# Altium schematic sheet 02_Endstufe.SchDoc(02_Endstufe)
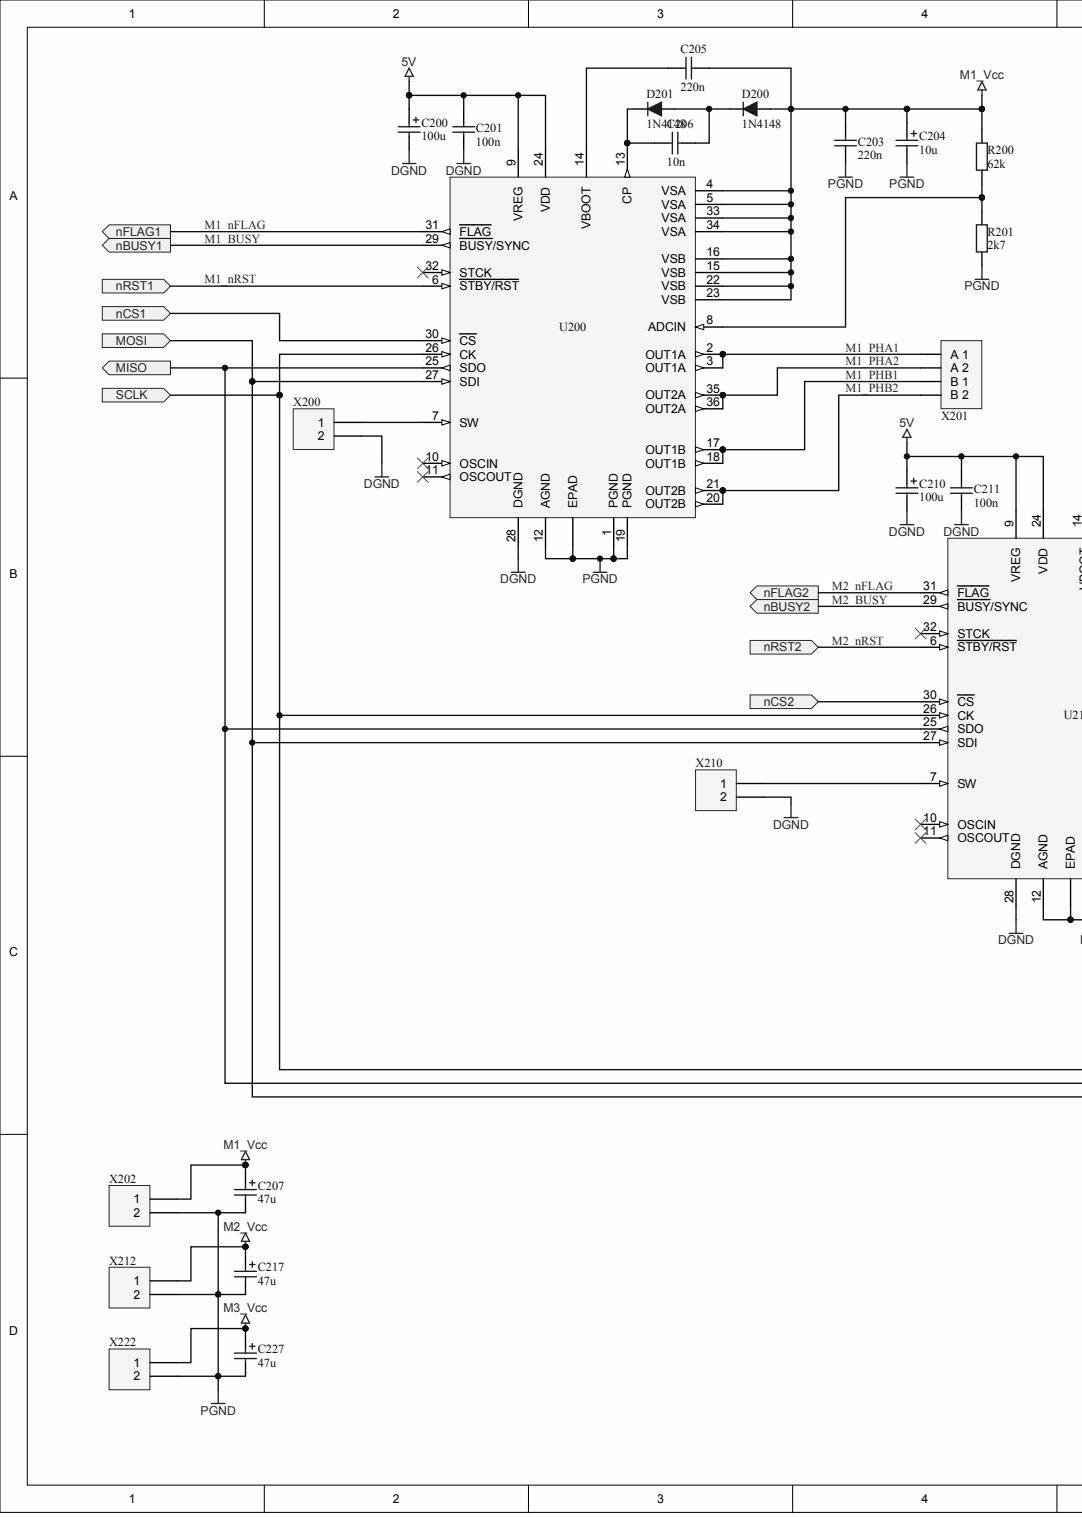
[diagram p0: 02_Endstufe.SchDoc, left]
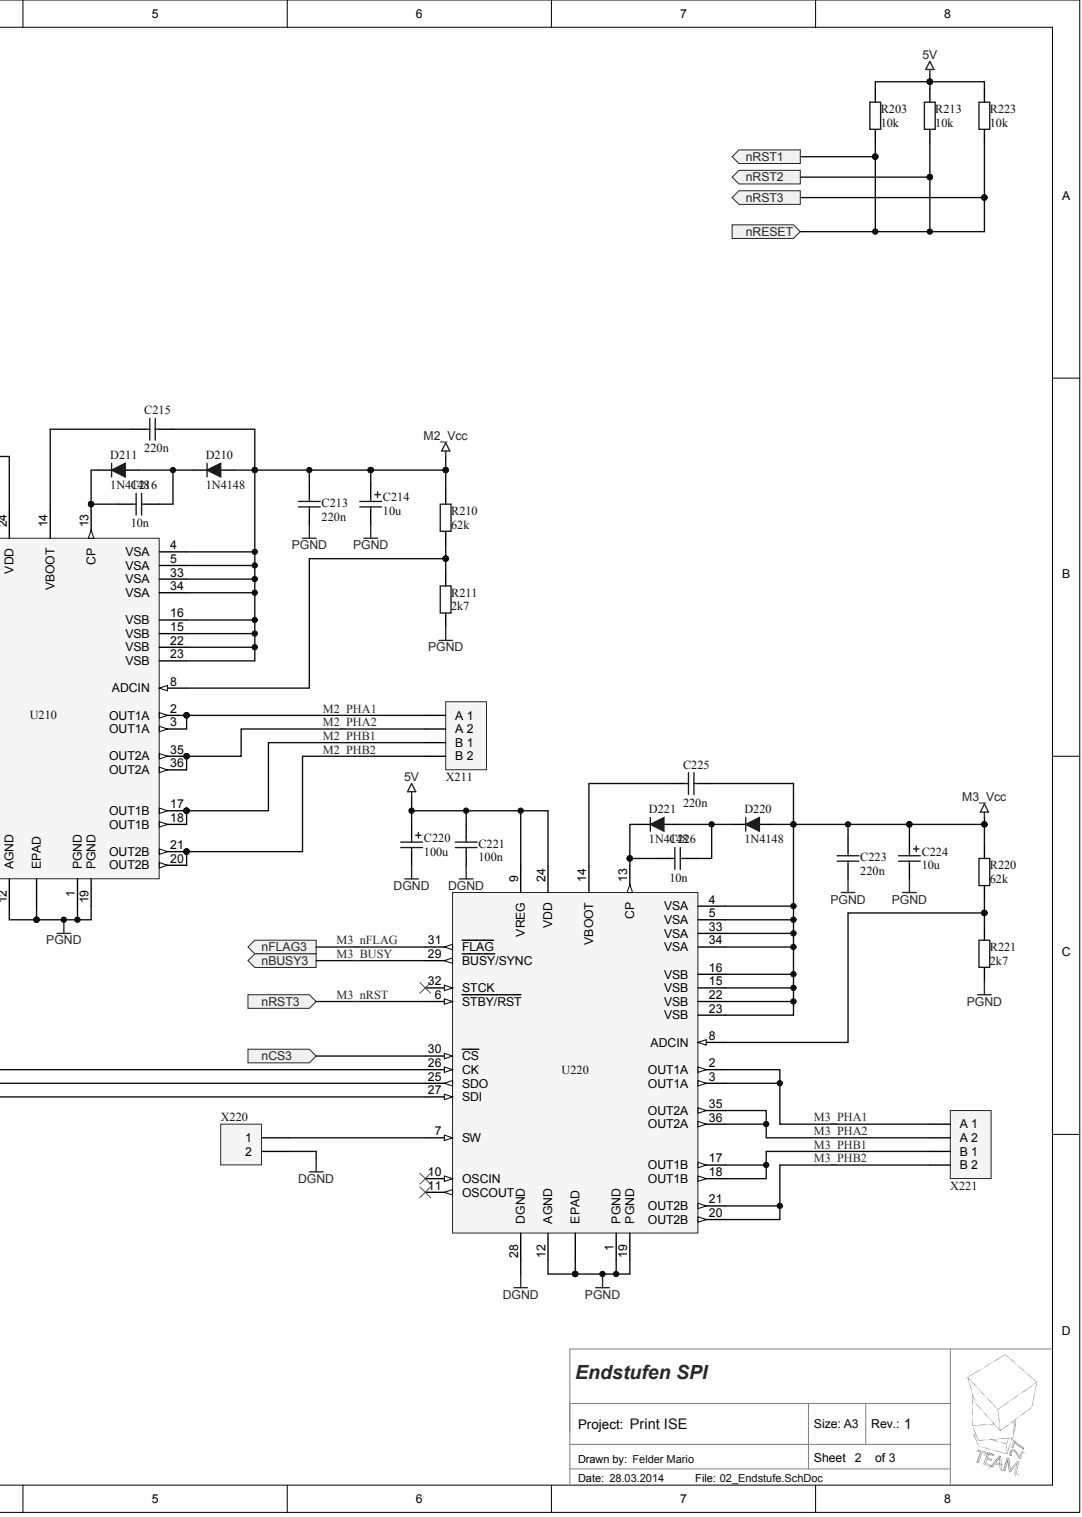
[diagram p1: 02_Endstufe.SchDoc, right]

## Parts
C200  100u
C201  100n
C203  220n
C204  10u
C205  220n
C206  10n
C207  47u
C210  100u
C211  100n
C213  220n
C214  10u
C215  220n
C216  10n
C217  47u
C220  100u
C221  100n
C223  220n
C224  10u
C225  220n
C226  10n
C227  47u
D200  1N4148
D201  1N4148
D210  1N4148
D211  1N4148
D220  1N4148
D221  1N4148
R200  62k
R201  2k7
R203  10k
R210  62k
R211  2k7
R213  10k
R220  62k
R221  2k7
R223  10k
U200  L6470 PD  (value not drawn)
U210  L6470 PD  (value not drawn)
U220  L6470 PD  (value not drawn)
X200  Header 2  (value not drawn)
X201  Motor_Connector  (value not drawn)
X202  Header 2  (value not drawn)
X210  Header 2  (value not drawn)
X211  Motor_Connector  (value not drawn)
X212  Header 2  (value not drawn)
X220  Header 2  (value not drawn)
X221  Motor_Connector  (value not drawn)
X222  Header 2  (value not drawn)

## Ports
MISO, MOSI, NBUSY1, NBUSY2, NBUSY3, NCS1, NCS2, NCS3, NFLAG1, NFLAG2, NFLAG3, NRESET, NRST1, NRST2, NRST3, SCLK

## Nets
5V: C200.1 C201.1 C210.1 C211.1 C220.1 C221.1 R203.2 R213.2 R223.2 U200.9(VREG) U200.24(VDD) U210.9(VREG) U210.24(VDD) U220.9(VREG) U220.24(VDD)
DGND: C200.2 C201.2 C210.2 C211.2 C220.2 C221.2 U200.28(DGND) U210.28(DGND) U220.28(DGND) X200.2 X210.2 X220.2
M1_VCC: C203.1 C204.1 C205.2 C207.1 D200.A R200.1 U200.4(VSA) U200.5(VSA) U200.15(VSB) U200.16(VSB) U200.22(VSB) U200.23(VSB) U200.33(VSA) U200.34(VSA) X202.1
M2_VCC: C213.1 C214.1 C215.2 C217.1 D210.A R210.1 U210.4(VSA) U210.5(VSA) U210.15(VSB) U210.16(VSB) U210.22(VSB) U210.23(VSB) U210.33(VSA) U210.34(VSA) X212.1
M3_VCC: C223.1 C224.1 C225.2 C227.1 D220.A R220.1 U220.4(VSA) U220.5(VSA) U220.15(VSB) U220.16(VSB) U220.22(VSB) U220.23(VSB) U220.33(VSA) U220.34(VSA) X222.1
PGND: C203.2 C204.2 C207.2 C213.2 C214.2 C217.2 C223.2 C224.2 C227.2 R201.2 R211.2 R221.2 U200.0(EPAD) U200.1(PGND) U200.12(AGND) U200.19(PGND) U210.0(EPAD) U210.1(PGND) U210.12(AGND) U210.19(PGND) U220.0(EPAD) U220.1(PGND) U220.12(AGND) U220.19(PGND) X202.2 X212.2 X222.2
M1_BUSY: U200.29(BUSY/SYNC) ; port NBUSY1
M1_NFLAG: U200.31(FLAG) ; port NFLAG1
M1_NRST: R203.1 R213.1 R223.1 U200.6(STBY/RST) U210.6(STBY/RST) U220.6(STBY/RST) ; port NRESET, port NRST1, port NRST2, port NRST3
M1_PHA1: U200.2(OUT1A) U200.3(OUT1A) X201.1(A_1)
M1_PHA2: U200.35(OUT2A) U200.36(OUT2A) X201.2(A_2)
M1_PHB1: U200.17(OUT1B) U200.18(OUT1B) X201.3(B_1)
M1_PHB2: U200.20(OUT2B) U200.21(OUT2B) X201.4(B_2)
M2_BUSY: U210.29(BUSY/SYNC) ; port NBUSY2
M2_NFLAG: U210.31(FLAG) ; port NFLAG2
M2_PHA1: U210.2(OUT1A) U210.3(OUT1A) X211.1(A_1)
M2_PHA2: U210.35(OUT2A) U210.36(OUT2A) X211.2(A_2)
M2_PHB1: U210.17(OUT1B) U210.18(OUT1B) X211.3(B_1)
M2_PHB2: U210.20(OUT2B) U210.21(OUT2B) X211.4(B_2)
M3_BUSY: U220.29(BUSY/SYNC) ; port NBUSY3
M3_NFLAG: U220.31(FLAG) ; port NFLAG3
M3_PHA1: U220.2(OUT1A) U220.3(OUT1A) X221.1(A_1)
M3_PHA2: U220.35(OUT2A) U220.36(OUT2A) X221.2(A_2)
M3_PHB1: U220.17(OUT1B) U220.18(OUT1B) X221.3(B_1)
M3_PHB2: U220.20(OUT2B) U220.21(OUT2B) X221.4(B_2)
MISO: U200.25(SDO) U210.25(SDO) U220.25(SDO) ; port MISO
MOSI: U200.27(SDI) U210.27(SDI) U220.27(SDI) ; port MOSI
SCLK: U200.26(CK) U210.26(CK) U220.26(CK) ; port SCLK
NetC205_1: C205.1 U200.14(VBOOT)
NetC206_1: C206.1 D201.K U200.13(CP)
NetC206_2: C206.2 D200.K D201.A
NetC215_1: C215.1 U210.14(VBOOT)
NetC216_1: C216.1 D211.K U210.13(CP)
NetC216_2: C216.2 D210.K D211.A
NetC225_1: C225.1 U220.14(VBOOT)
NetC226_1: C226.1 D221.K U220.13(CP)
NetC226_2: C226.2 D220.K D221.A
NetR200_2: R200.2 R201.1 U200.8(ADCIN)
NetR210_2: R210.2 R211.1 U210.8(ADCIN)
NetR220_2: R220.2 R221.1 U220.8(ADCIN)
NetU200_7: U200.7(SW) X200.1
NetU200_30: U200.30(CS) ; port NCS1
NetU210_7: U210.7(SW) X210.1
NetU210_30: U210.30(CS) ; port NCS2
NetU220_7: U220.7(SW) X220.1
NetU220_30: U220.30(CS) ; port NCS3

Not connected: U200 10(OSCIN) 11(OSCOUT) 32(STCK); U210 10(OSCIN) 11(OSCOUT) 32(STCK); U220 10(OSCIN) 11(OSCOUT) 32(STCK)

## Library data of the parts
C200 C204 C207 C210 C214 C217 C220 C224 C227 = (no symbol name): Value: 100pF; Published: 8-Jun-2000; LatestRevisionDate: 17-Jul-2002; LatestRevisionNote: Re-released for DXP Platform.; PackageReference: POLAR0.8; PackageDescription: Polarized Capacitor; 2 Leads; Publisher: Altium Limited
C201 C203 C205 C206 C211 C213 C215 C216 C221 C223 C225 C226 D200 D201 D210 D211 D220 D221 R200 R201 R203 R210 R211 R213 R220 R221 R223 U200 U210 U220 X200-X202 X210-X212 X220-X222 = (no symbol name)
  C201 C203 C205 C206 C211 C213 C215 C216 C221 C223 C225 C226: PackageReference: 0805
  D200 D201 D210 D211 D220 D221: PackageReference: MiniMELF SOD-80; Supplier 1: Mouser; Supplier Part Number 1: 78-LL4148
  R200 R201 R203 R210 R211 R213 R220 R221 R223: PackageReference: 0805; PackageDescription: SMD
  U200 U210 U220: Supplier 1: Mouser; Supplier Part Number 1: 511-L6470PD
  X200 X202 X210 X212 X220 X222: LatestRevisionDate: 17-Jul-2002; LatestRevisionNote: Re-released for DXP Platform.; Publisher: Altium Limited
